# Altium schematic sheet LED_Crystal_V4.0.SchDoc(LED_Crystal_V4.0)
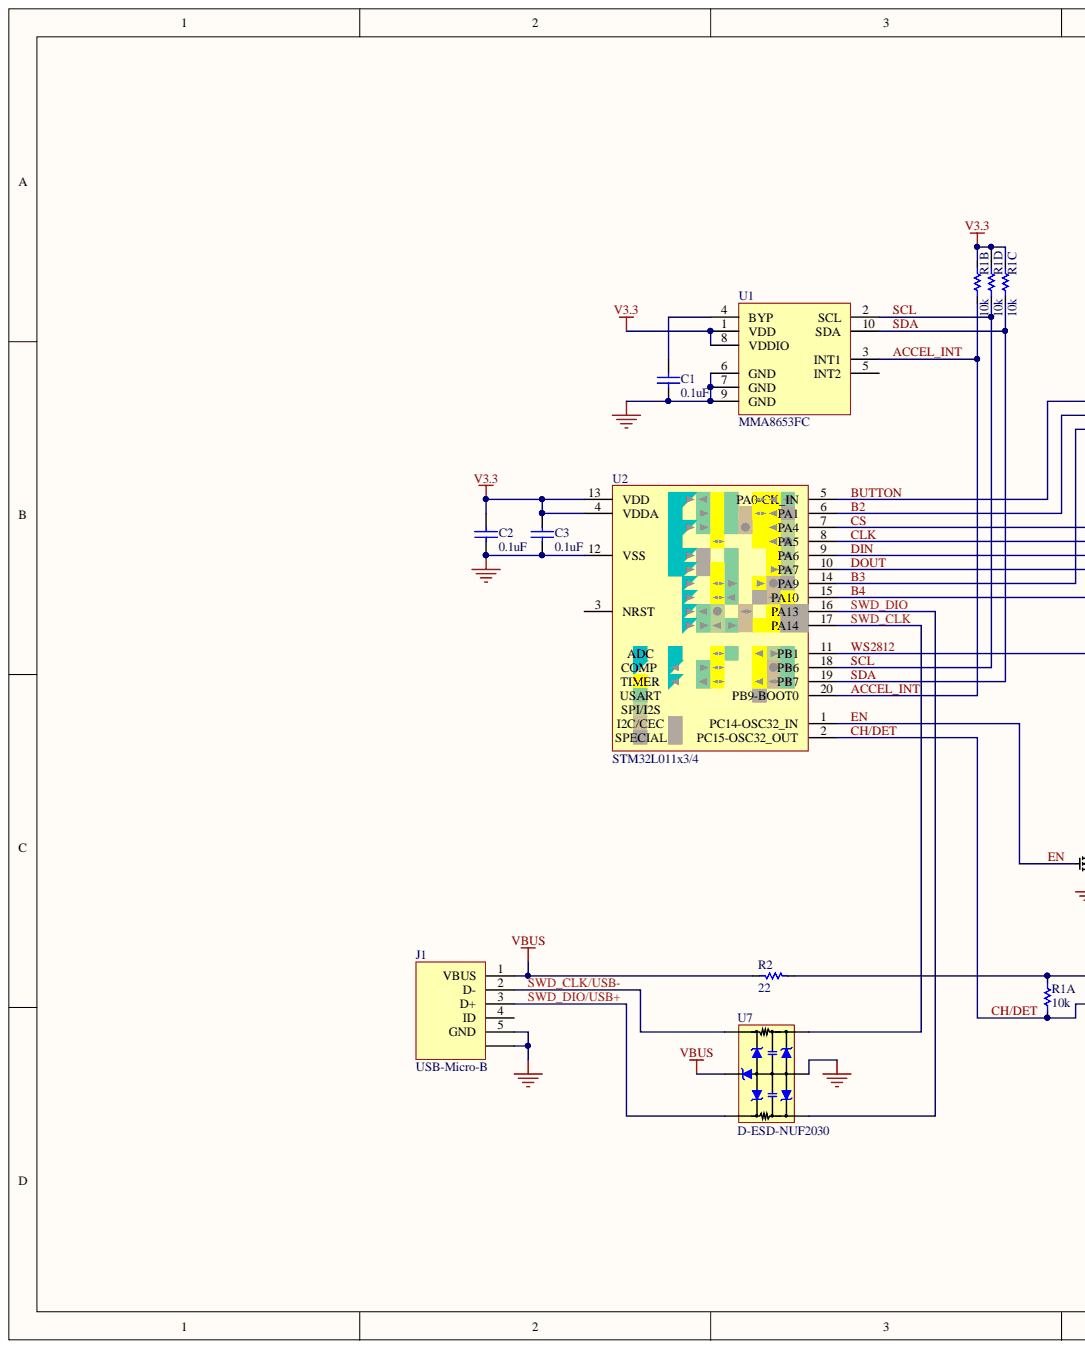
[diagram p0: LED_Crystal_V4.0.SchDoc, left]
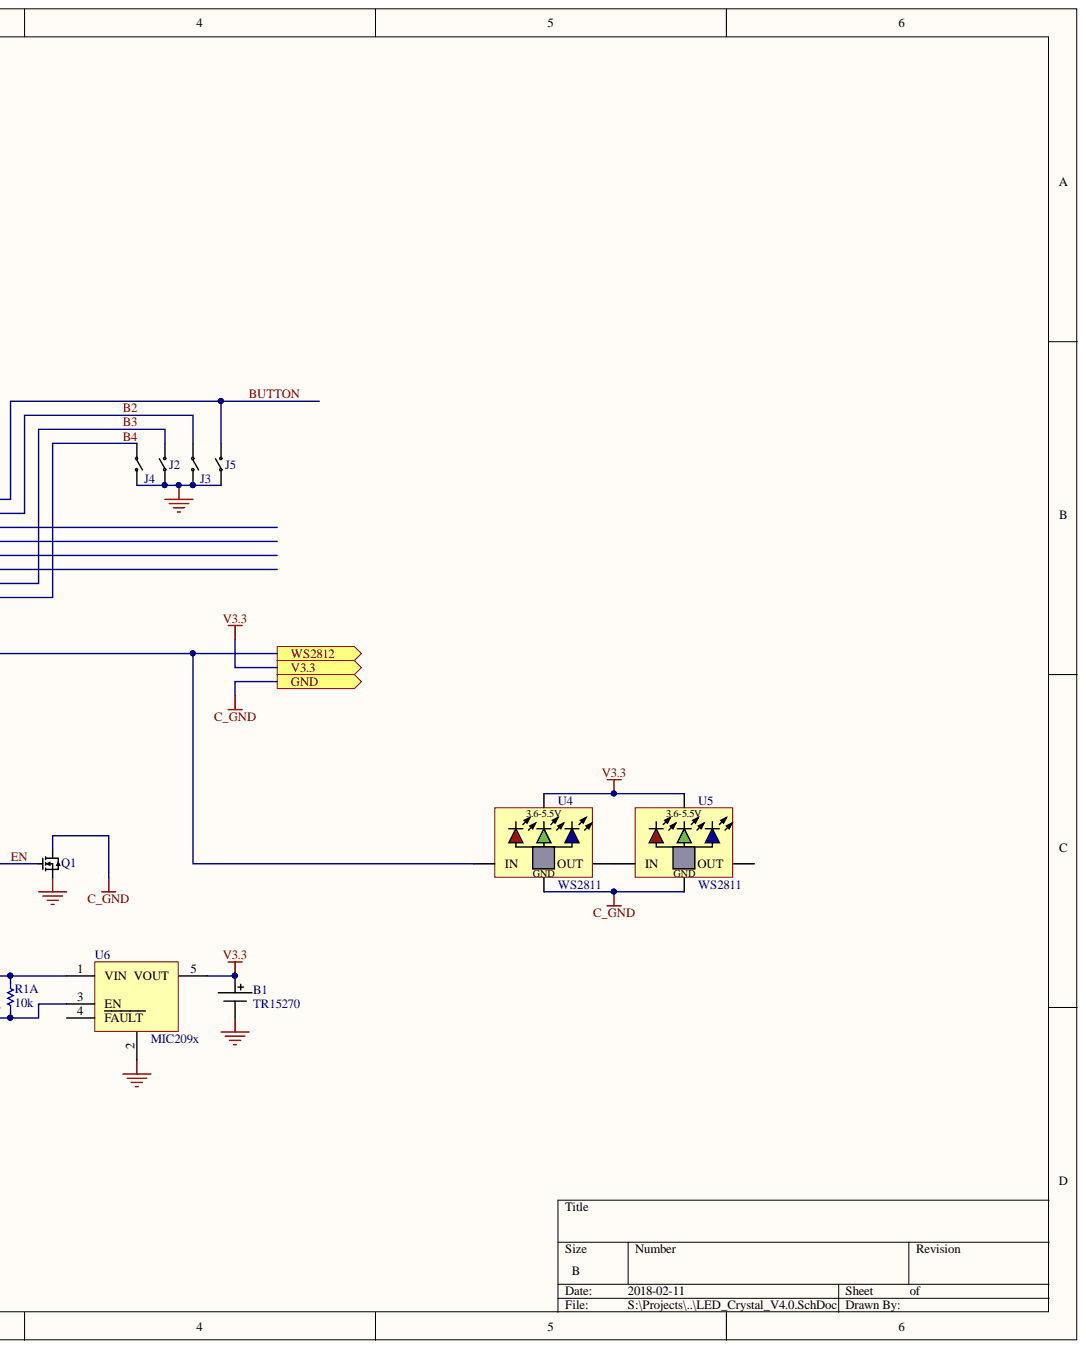
[diagram p1: LED_Crystal_V4.0.SchDoc, right]

## Parts
B1  TR15270  [BAT-815-0931]
C1  0.1uF  [CAP-0402]
C2  0.1uF  [CAP-0402]
C3  0.1uF  [CAP-0402]
J1  USB-Micro-B  [USB-MICRO-HORZ-SEALED]
J2  Button  [BUTTON-PTS840-PIP]  (value not drawn)
J3  Button  [BUTTON-PTS840-PIP]  (value not drawn)
J4  Button  [BUTTON-PTS840-PIP]  (value not drawn)
J5  Button  [BUTTON-PTS840-PIP]  (value not drawn)
Q1  MOSFET-BSS138W  [MOSFET-BSS138W-SC-70]  (value not drawn)
R1  10k  [RESx4-0603x4]  (parts A,B,C,D)
R2  22  [RES-0402]
U1  MMA8653FC  [MMA8653FC]
U2  STM32L011x3/4  [STM32L011x3/4-QFN20]
U4  WS2811  [LED-WS2812B]
U5  WS2811  [LED-WS2812B]
U6  MIC209x  [MIC209X-SOT23-5]
U7  D-ESD-NUF2030  [D-ESD-SOT-563-6Lead]

## Ports
GND, V3.3, WS2812

## Nets
GND: B1.NEG C1.2 C2.1 C3.1 J1.5(GND) J1.CASE J2.1 J3.2 J4.2 J5.1 Q1.S U1.6(GND) U1.7(GND) U1.9(GND) U2.12(VSS) U6.2 U7.2
VBUS: J1.1(VBUS) R2.2 U7.5
ACCEL_INT: R1.4 U1.3(INT1) U2.20(PB9-BOOT0)
B2: J3.1 U2.6(PA1)
B3: J2.2 U2.14(PA9)
B4: J4.1 U2.15(PA10)
BUTTON: J5.2 U2.5(PA0-CK_IN)
C_GND: Q1.D U4.2 U5.2 ; port GND
CH/DET: R1.2 U2.2(PC15-OSC32_OUT) U6.3(EN)
CLK: U2.8(PA5)
CS: U2.7(PA4)
DIN: U2.9(PA6)
DOUT: U2.10(PA7)
EN: Q1.G U2.1(PC14-OSC32_IN)
SCL: R1.8 U1.2(SCL) U2.18(PB6)
SDA: R1.6 U1.10(SDA) U2.19(PB7)
SWD_CLK: U2.17(PA14) U7.1
SWD_CLK/USB-: J1.2(D-) U7.6
SWD_DIO: U2.16(PA13) U7.3
SWD_DIO/USB+: J1.3(D+) U7.4
V3.3: B1.POS C2.2 C3.2 R1.3 R1.5 R1.7 U1.1(VDD) U1.8(VDDIO) U2.4(VDDA) U2.13(VDD) U4.4 U5.4 U6.5(VOUT) ; port V3.3
WS2812: U2.11(PB1) U4.3(IN) ; port WS2812
NetC1_1: C1.1 U1.4(BYP)
NetR1_1: R1.1 R2.1 U6.1(VIN)
NetU4_1: U4.1(OUT) U5.3(IN)

Not connected: J1 4(ID); U1 5(INT2); U2 3(NRST); U5 1(OUT); U6 4(FAULT)

## Library data of the parts
B1 = BATTERY: Library Name: MiscHeaders.SchLib; Component Kind: Standard
C1-C3 = CAP: Capacitor; Value: 0.1uF; Simulation: CAP; Signal Integrity: Cap; PackageDescription: Capacitor; 2 Leads; Library Name: Discretes.SchLib; Component Kind: Standard
J1 = USB-Micro-B: USB Micro-B; Library Name: MiscHeaders.SchLib; digikey: H12192CT-ND; Component Kind: Standard
Q1 = MOSFET-BSS138W: Nch 60V 1.0Vg 0.28A; Library Name: Discretes.SchLib; Component Kind: Standard
R1 = RESx4_sep: 4x discrete diodes; Value: 10k; Library Name: Discretes.SchLib; Component Kind: Standard
R2 = RES: Resistor; Value: 22; Simulation: RESISTOR; Signal Integrity: Res; Published: 8-Jun-2000; PackageReference: AXIAL-0.3; PackageDescription: Axial Device, Thru-Hole; 2 Leads; 0.3 in Pin Spacing; Library Name: Discretes.SchLib; LatestRevisionNote: Re-released for DXP Platform.; LatestRevisionDate: 17-Jul-2002; Digikey: 311-10KLDTR-ND; Component Kind: Standard; Publisher: Altium Limited
U1 = MMA8653FC: Xtrinsic MMA8653FC 3-Axis, 10-bit   Digital Accelerometer; Component Kind: Standard; Library Name: MixedSignal-DataConverters.SchLib
U2 = STM32L011x3/4: STM32L011x3/4 in a QFN20 package; Library Name: ARM-Controllers.SchLib; Component Kind: Standard
U4 U5 = LED-RGB-WS2811: Library Name: Discretes.SchLib; Component Kind: Standard
J2-J5 = Button: Library Name: Hardware.SchLib; Component Kind: Standard
U6 = MIC209x: Library Name: PowerSupplies.SchLib; HelpURL: http://ww1.microchip.com/downloads/en/DeviceDoc/MIC2090_1.pdf; digikey 50mA: 576-3890-1-ND; digikey: 576-3891-1-ND; Component Kind: Standard
U7 = D-ESD-NUF2030: Library Name: Discretes.SchLib; HelpURL: http://www.onsemi.com/pub/Collateral/NUF2030XV6-D.PDF; digikey: https://www.digikey.ca/products/en?keywords=NUF2042XV6T1GOSCT-ND; Component Kind: Standard
